# Altium schematic sheet LoRa.SchDoc
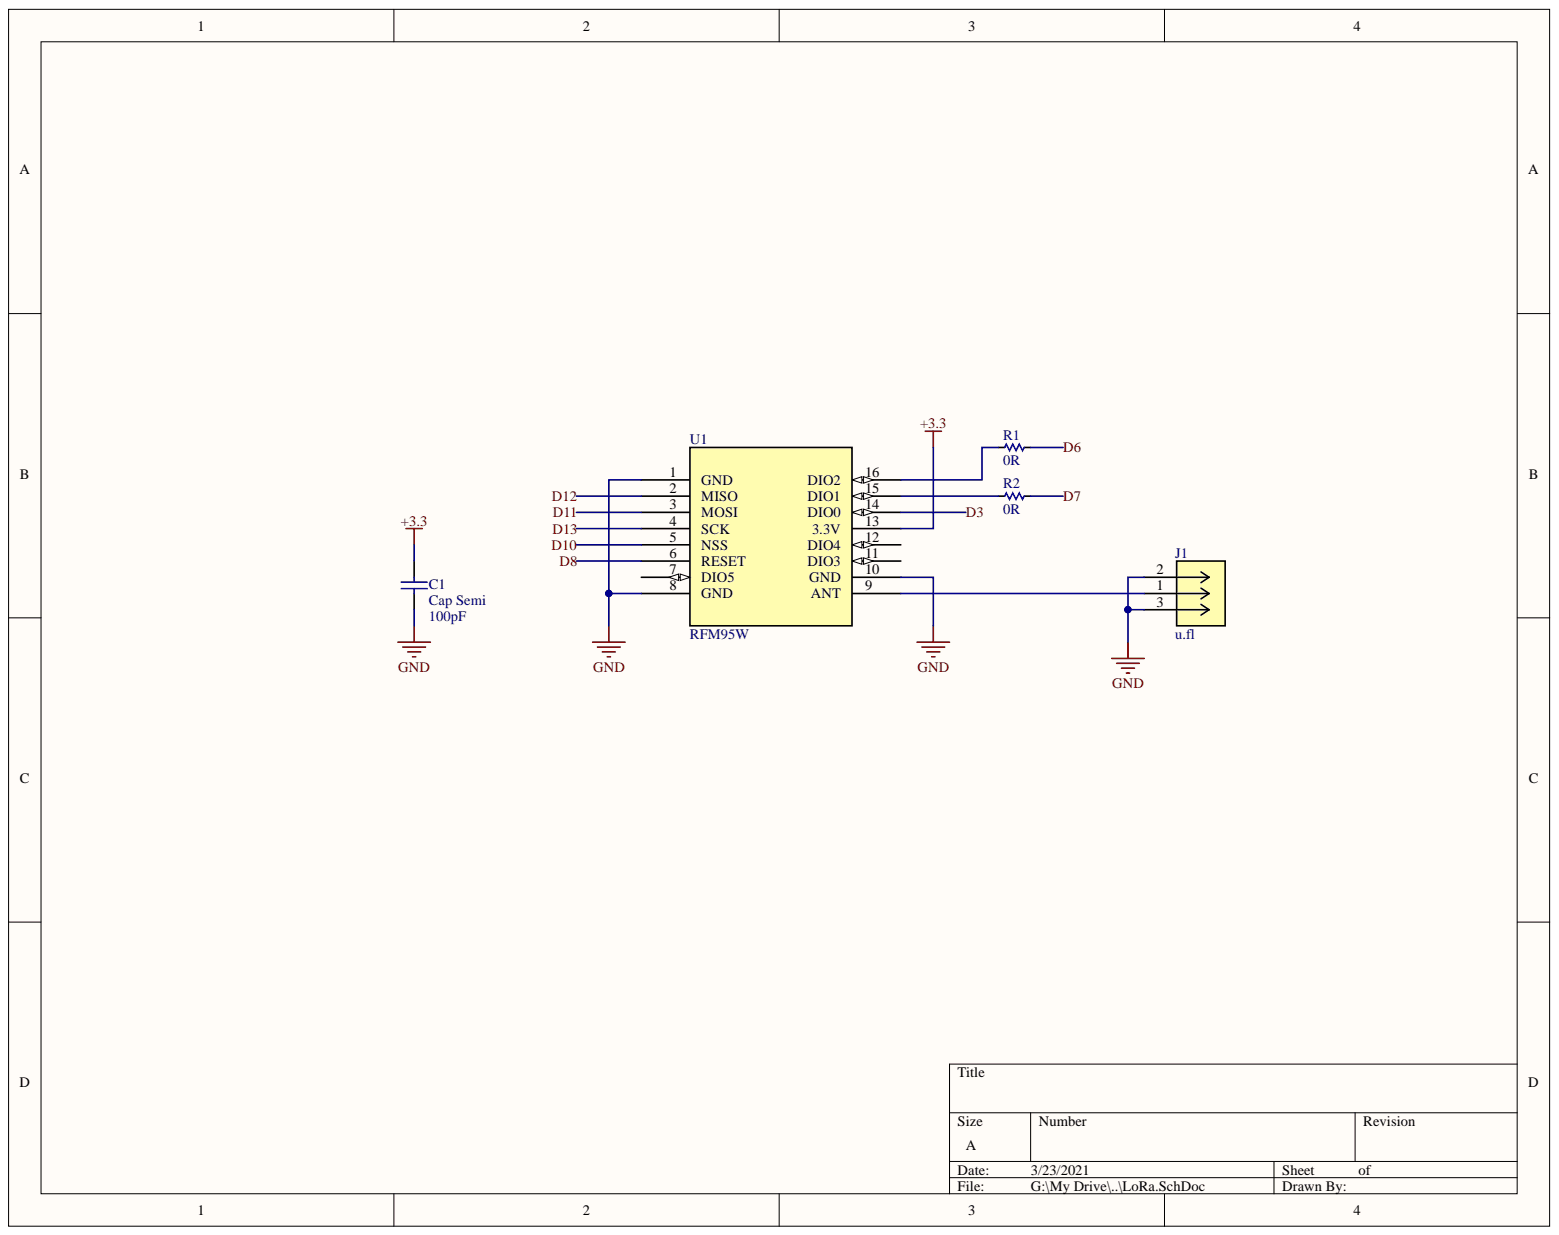
[diagram p0: LoRa.SchDoc]

## Parts
C1  Cap Semi  value 100pF  [1608[0603]]
J1  u.fl  [UFL Connector]
R1  0R  [RES-SMD-0603]
R2  0R  [RES-SMD-0603]
U1  RFM95W  [IC16_RFM95W]

## Nets
+3.3: C1.2 U1.13(3.3V)
GND: C1.1 J1.2 J1.3 U1.1(GND) U1.8(GND) U1.10(GND)
D3: U1.14(DIO0)
D6: R1.2
D7: R2.2
D8: U1.6(RESET)
D10: U1.5(NSS)
D11: U1.3(MOSI)
D12: U1.2(MISO)
D13: U1.4(SCK)
NetJ1_1: J1.1 U1.9(ANT)
NetR1_1: R1.1 U1.16(DIO2)
NetR2_1: R2.1 U1.15(DIO1)

Not connected: U1 7(DIO5) 11(DIO3) 12(DIO4)

## Library data of the parts
C1 = Cap Semi: Capacitor (Semiconductor SIM Model); Component Kind: Standard; LatestRevisionDate: 29-May-2009; LatestRevisionNote: IPC-7351 Footprint Added.; Library Name: Miscellaneous Devices.IntLib; PackageDescription: Chip Capacitor; PackageReference: C1206; Published: 8-Jun-2000; Signal Integrity: Cap Semi; Simulation: CAP; Publisher: Altium Limited
J1 = U.FL-R-SMT: No Description Available; Manufacturer_Part_Number: U.FL-R-SMT-1(10); Built_By: EMA_Matt; Vendor: Hirose; Component Kind: Standard; Library Name: MyLibrary-001.IntLib; RefDes: RefDes; Type: DEV; Value: Value; Copyright: Copyright (C) 2018 Accelerated Designs. All rights reserved
R1 R2 = Res-SMD: Resistor; Manufacturer: Generic Components; Class: Passive; Component Kind: Standard; Library Name: LIBRARY.IntLib; Published: 08/06/2000; Value: 0R; Publisher: Altium Hobart Technology Centre
U1 = RFM95W: No Description Available; Manufacturer_Part_Number: RFM95W-915S2; Built_By: EMA_Kyle; SourceLibrary: Add_to_database_2020-10-19; Vendor: RF Solutions; Component Kind: Standard; Datasheet: https://www.rfsolutions.co.uk/downloads/1463993415RFM95_96_97_98W.pdf; Library Name: RFM95W.IntLib; RefDes: RefDes; Type: DEV; Copyright: Copyright (C) 2018 Accelerated Designs. All rights reserved
